# Altium schematic sheet Uart.SchDoc
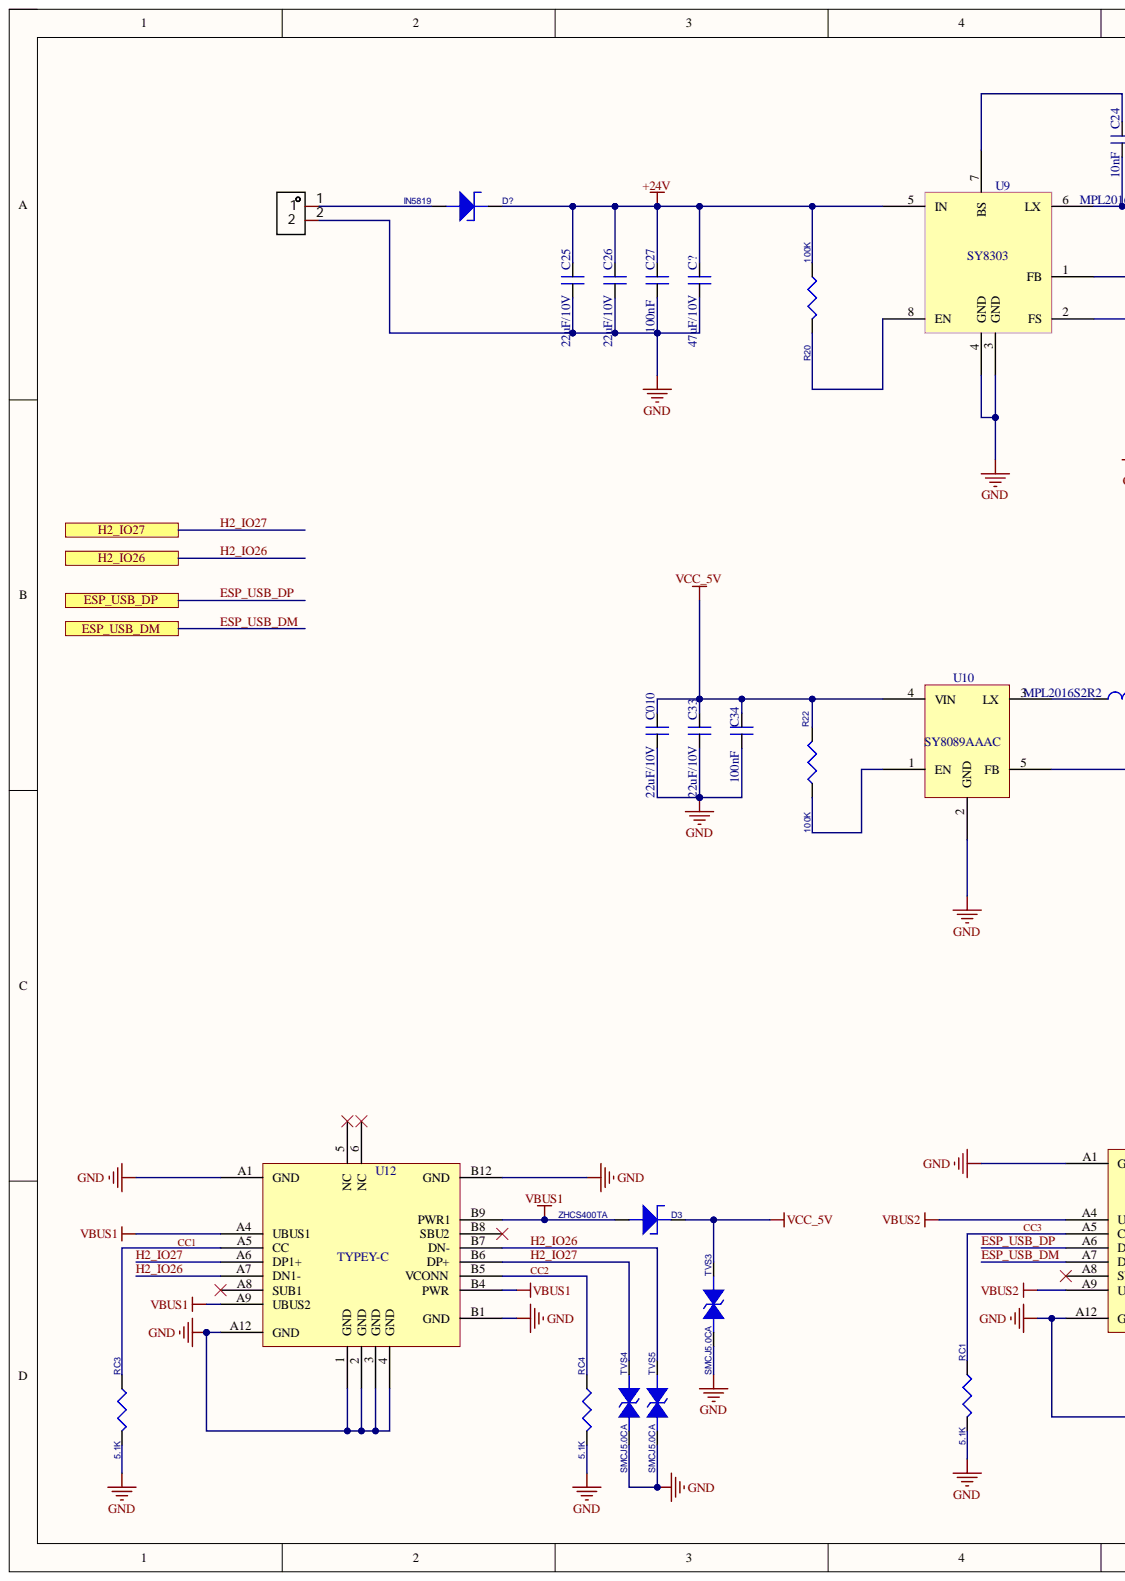
[diagram p0: Uart.SchDoc, left]
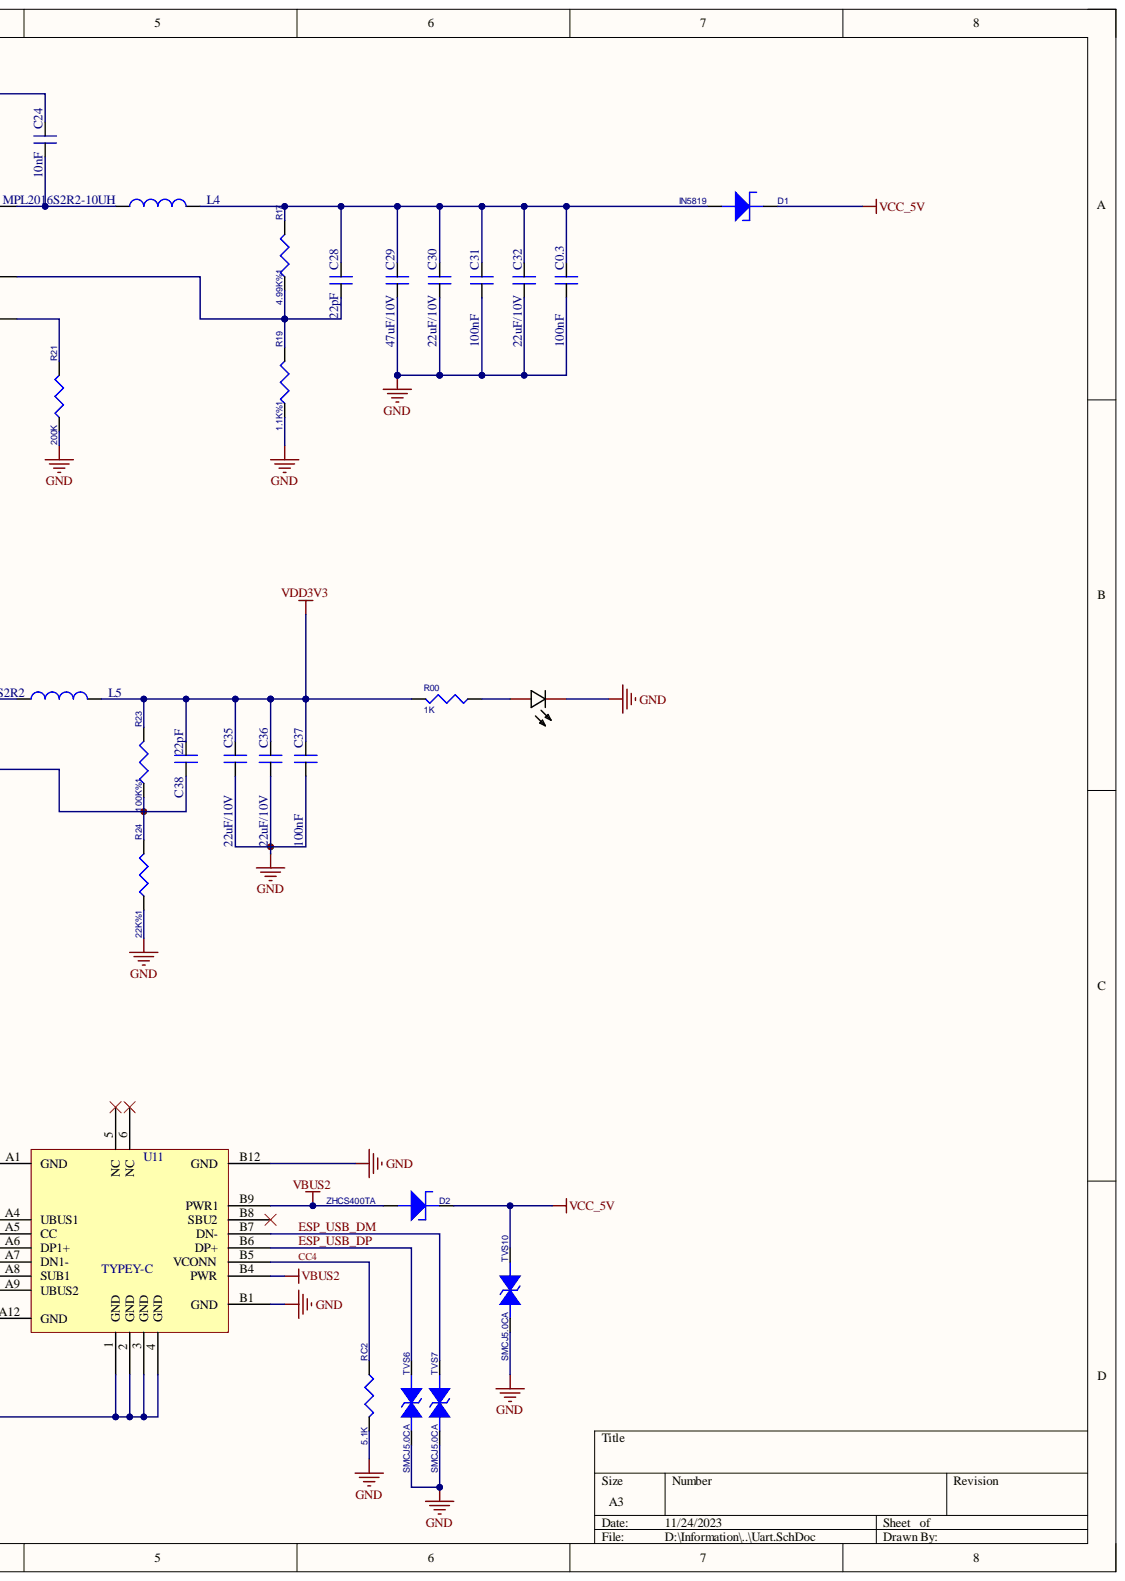
[diagram p1: Uart.SchDoc, right]

## Parts
C0.3  100nF  [LC-0402_C]
C010  22uF/10V  [LC-0805_C]
C24  10nF  [LC-0603_C]
C25  22uF/10V  [CAP-SMD_BD6.3-L6.6-W6.6-LS7.2-FD]
C26  22uF/10V  [LC-0805_C]
C27  100nF  [LC-0603_C]
C28  22pF  [LC-0402_C]
C29  47uF/10V  [LC-1210_C]
C30  22uF/10V  [LC-0805_C]
C31  100nF  [LC-0603_C]
C32  22uF/10V  [LC-1210_C]
C33  22uF/10V  [LC-0805_C]
C34  100nF  [LC-0603_C]
C35  22uF/10V  [LC-0805_C]
C36  22uF/10V  [LC-0805_C]
C37  100nF  [LC-0603_C]
C38  22pF  [LC-0402_C]
C?  47uF/10V  [LC-1210_C]
CN1  KF250NH-3.81-2P  [CONN-TH_P3.96_HT396R-3.96-2P]  (value not drawn)
D1  IN5819  [DO-214AC]
D2  ZHCS400TA  [SDO123]
D3  ZHCS400TA  [SDO123]
D?  IN5819  [DO-214AC]
L4  MPL2016S2R2-10UH  [IND-SMD_L3.0-W3.0_SWPA3010S]
L5  MPL2016S2R2  [IND-SMD_L2.5-W2.0]
LED1  NCD0603R1_C84263  [LED0603-RD_RED]  (value not drawn)
R00  1K  [LC-0402_R]
R17  4.99K%1  [LC-0402_R]
R19  1.1K%1  [LC-0402_R]
R20  100K  [LC-0402_R]
R21  200K  [LC-0402_R]
R22  100K  [LC-0402_R]
R23  100K%1  [LC-0402_R]
R24  22K%1  [LC-0402_R]
RC1  5.1K  [LC-0402_R]
RC2  5.1K  [LC-0402_R]
RC3  5.1K  [LC-0402_R]
RC4  5.1K  [LC-0402_R]
TVS3  SMCJ5.0CA  [LC-SOD-323]
TVS4  SMCJ5.0CA  [LC-0402_R]
TVS5  SMCJ5.0CA  [LC-0402_R]
TVS6  SMCJ5.0CA  [LC-0402_R]
TVS7  SMCJ5.0CA  [LC-0402_R]
TVS10  SMCJ5.0CA  [LC-SOD-323]
U9  SY8303  [TSOT-23-8_L2.9-W1.6-P0.65-LS2.8-BR]
U10  SY8089AAAC  [LC-SOT-23-5]
U11  TYPEY-C  [TYPE-C-TH_16PLC-H10.0]
U12  TYPEY-C  [TYPE-C-TH_16PLC-H10.0]

## Ports
ESP_USB_DM, ESP_USB_DP, H2_IO26, H2_IO27

## Nets
+24V: C25.1 C26.1 C27.1 C?.1 D?.K R20.1 U9.5(IN)
GND: C0.3.2 C010.2 C25.2 C26.2 C27.2 C29.2 C30.2 C31.2 C32.2 C33.2 C34.2 C35.2 C36.2 C37.2 C?.2 CN1.2 LED1.1 R19.1 R21.1 R24.1 RC1.2 RC2.2 RC3.2 RC4.2 TVS3.1 TVS4.1 TVS5.1 TVS6.1 TVS7.1 TVS10.1 U9.3(GND) U9.4(GND) U10.2(GND) U11.1(GND) U11.2(GND) U11.3(GND) U11.4(GND) U11.A1(GND) U11.A12(GND) U11.B1(GND) U11.B12(GND) U12.1(GND) U12.2(GND) U12.3(GND) U12.4(GND) U12.A1(GND) U12.A12(GND) U12.B1(GND) U12.B12(GND)
VBUS1: D3.1 U12.A4(UBUS1) U12.A9(UBUS2) U12.B4(PWR) U12.B9(PWR1)
VBUS2: D2.1 U11.A4(UBUS1) U11.A9(UBUS2) U11.B4(PWR) U11.B9(PWR1)
VCC_5V: C010.1 C33.1 C34.1 D1.K D2.2 D3.2 R22.2 TVS3.2 TVS10.2 U10.4(VIN)
VDD3V3: C35.1 C36.1 C37.1 C38.1 L5.2 R00.2 R23.2
CC1: RC3.1 U12.A5(CC)
CC2: RC4.1 U12.B5(VCONN)
CC3: RC1.1 U11.A5(CC)
CC4: RC2.1 U11.B5(VCONN)
ESP_USB_DM: TVS7.2 U11.A7(DN1-) U11.B7(DN-) ; port ESP_USB_DM
ESP_USB_DP: TVS6.2 U11.A6(DP1+) U11.B6(DP+) ; port ESP_USB_DP
H2_IO26: TVS5.2 U12.A7(DN1-) U12.B7(DN-) ; port H2_IO26
H2_IO27: TVS4.2 U12.A6(DP1+) U12.B6(DP+) ; port H2_IO27
NetC0.3_1: C0.3.1 C28.1 C29.1 C30.1 C31.1 C32.1 D1.A L4.2 R17.2
NetC24_1: C24.1 U9.7(BS)
NetC24_2: C24.2 L4.1 U9.6(LX)
NetC28_2: C28.2 R17.1 R19.2 U9.1(FB)
NetC38_2: C38.2 R23.1 R24.2 U10.5(FB)
NetCN1_1: CN1.1 D?.A
NetL5_1: L5.1 U10.3(LX)
NetLED1_2: LED1.2 R00.1
NetR20_2: R20.2 U9.8(EN)
NetR21_2: R21.2 U9.2(FS)
NetR22_1: R22.1 U10.1(EN)

Not connected: U11 5(NC) 6(NC) A8(SUB1) B8(SBU2); U12 5(NC) 6(NC) A8(SUB1) B8(SBU2)

## Library data of the parts
C0.3 C010 C24-C38 C? = C: Component Kind: Standard; Library Name: K210--Crazy.SCHLIB
CN1 = KF250NH-3.81-2P: Manufacturer: KEFA(科发); Add into BOM: yes; Current Rating (Max): 15A; Number of PINs Per Row: 2; Pitch: 3.81mm; Voltage Rating (Max): 250V; Wire Gauge - AWG: 16~20; Wire Gauge - mm2: 1.5; Color: 绿色; Component Kind: Standard; Convert to PCB: yes; Datasheet: https://atta.szlcsc.com/upload/public/pdf/source/20210104/C976575_A0E34178A64A6FB2BFF412AB39272803.pdf; Device: KF250NH-3.81-2P; JLCPCB Part Class: Extended Part; LCSC Part Name: 3.81mm 每排P数:2 排数:1; Manufacturer Part: KF250NH-3.81-2P; Mounting Style: 通孔; Name: ='KEFA(科发)'Part; Number of Rows: 1; Operating Temperature Range: -40℃~+105℃; Supplier: LCSC; Supplier Footprint: 插件,P=3.81mm; Supplier Part: C976576; Symbol: KF250NH-3.81-2P
D1-D3 D? = DIODE ZENER_4_1: room: power1; Component Kind: Standard; Library Name: 光学蓝牙.SCHLIB
L4 L5 = Inductor: Code_IEC: 1005; Value: 10mH; Code_JEITA: 0402; Component Kind: Standard; Description: Inductor; LatestRevisionDate: 29-May-2009; LatestRevisionNote: IPC-7351 Footprint Added.; Library Name: Miscellaneous Devices.IntLib; PackageDescription: Chip Inductor; PackageReference: 0402-A; Published: 8-Jun-2000; Publisher: Altium Limited
LED1 = NCD0603R1_C84263: Manufacturer: 国星光电; Add into BOM: yes; Emitted Color: 红灯; Symbol: FC-DA1608HRK-620D; Component Kind: Standard; Convert to PCB: yes; Datasheet: https://atta.szlcsc.com/upload/public/pdf/source/20200820/C84263_DABC6A75E58F576973B407FDBA283D60.pdf; Device: NCD0603R1_C84263; JLCPCB Part Class: Extended Part; LCSC Part Name: 红灯; Manufacturer Part: NCD0603R1; Name: ='国星光电'Part; Supplier: LCSC; Supplier Footprint: 0603; Supplier Part: C84263
R00 R17 R19-R24 = Res0603: Component Kind: Standard; Library Name: K210--Crazy.SCHLIB
RC1-RC4 = R: Component Kind: Standard; Library Name: 光学蓝牙.SCHLIB
TVS3-TVS7 TVS10 = ESD: Component Kind: Standard
U9 = SY8025: Component Kind: Standard; Library Name: 885阿里云PCB_Project1.SCHLIB
U10 = SY8089AAAC: Component Kind: Standard; Library Name: K210--Crazy.SCHLIB
U11 U12 = TYPEY-C: Component Kind: Standard; Library Name: 885阿里云PCB_Project1.SCHLIB
